# Altium schematic sheet [10] - HDMI.SchDoc
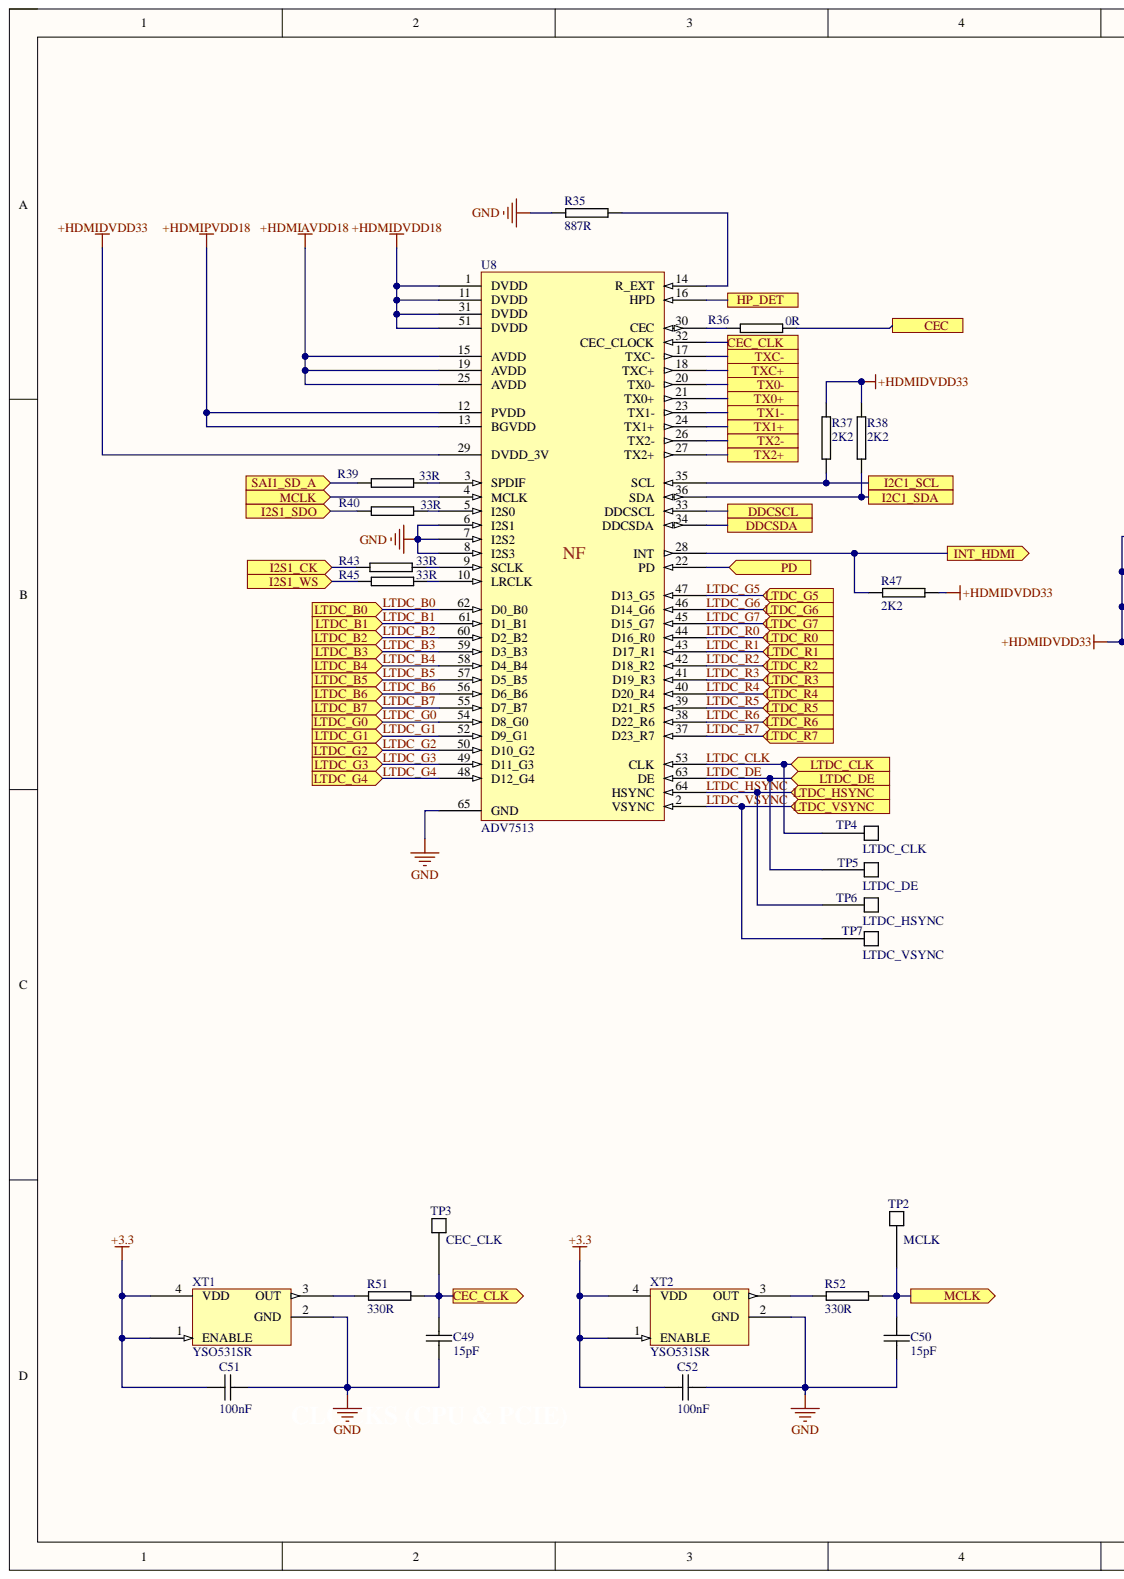
[diagram p0: [10] - HDMI.SchDoc, left]
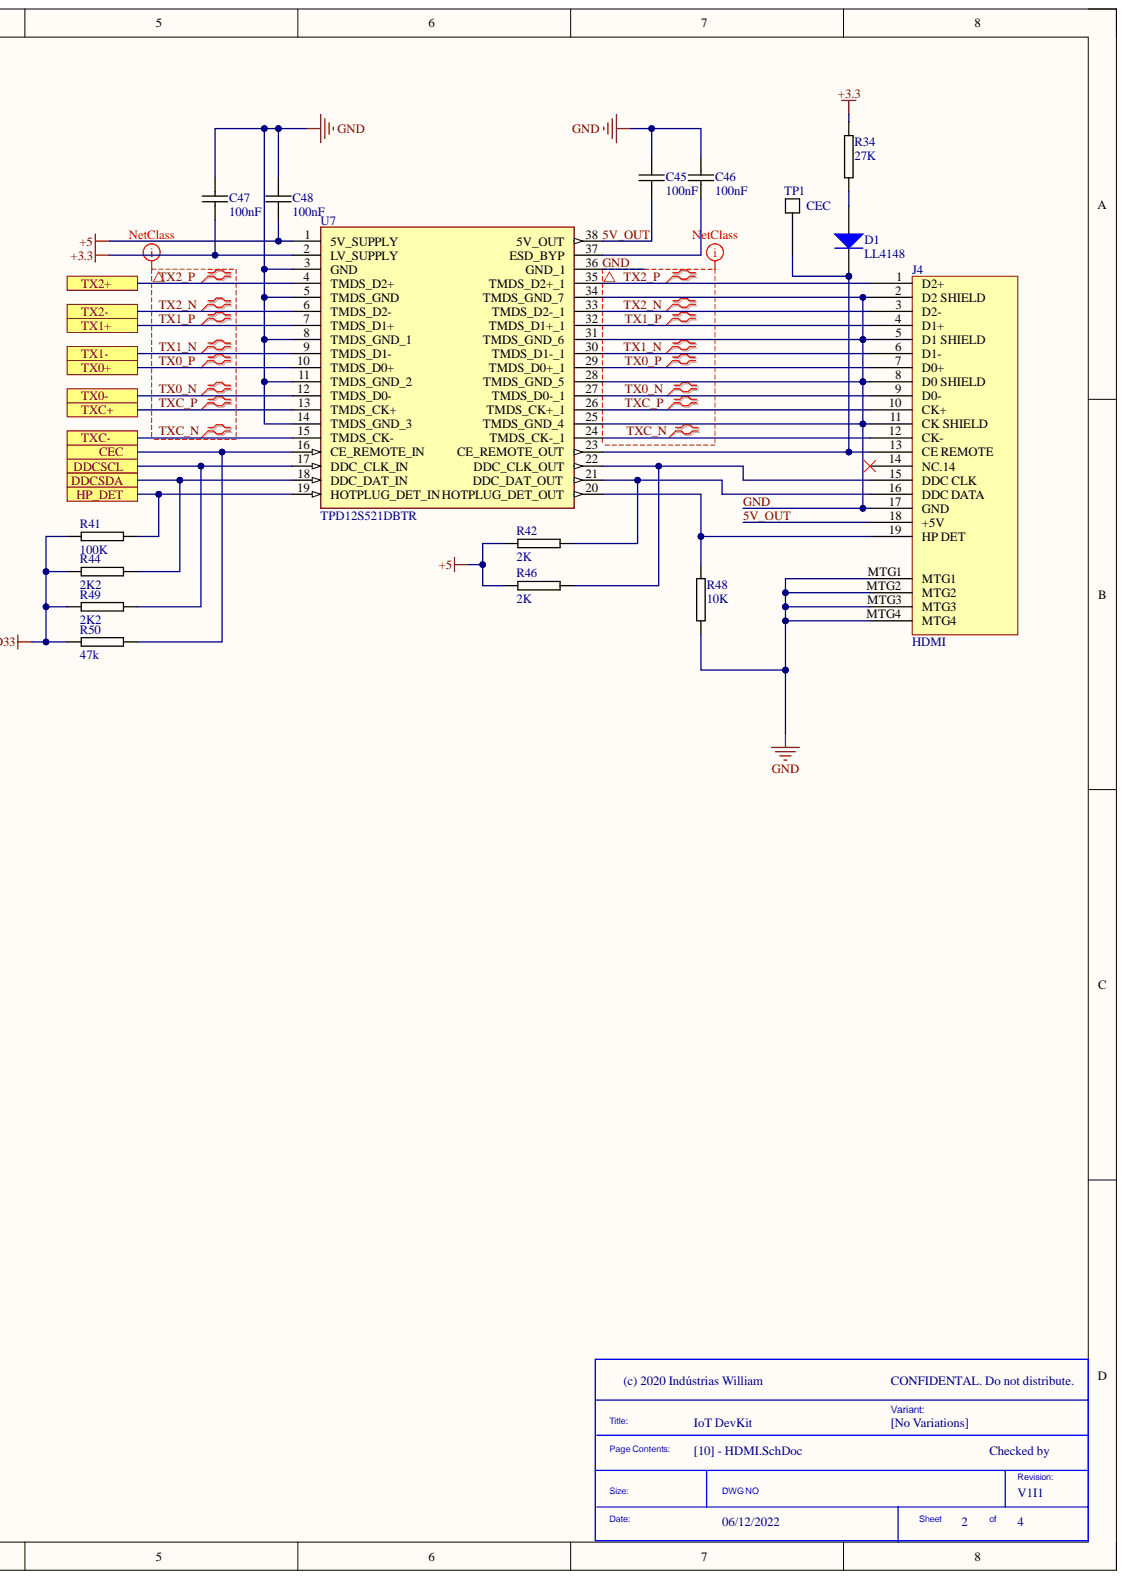
[diagram p1: [10] - HDMI.SchDoc, right]

## Parts
C45  100nF  [0603C-GENERIC]
C46  100nF  [0603C-GENERIC]
C47  100nF  [0603C-GENERIC]
C48  100nF  [0603C-GENERIC]
C49  15pF  [0603C-GENERIC]
C50  15pF  [0603C-GENERIC]
C51  100nF  [0603C-GENERIC]
C52  100nF  [0603C-GENERIC]
D1  LL4148  [MELFDIODE]
J4  HDMI  [HDMI]
R34  27K  [0603R-27K]
R35  887R  [0603R-100]
R36  0R  [0603R-0R]
R37  2K2  [0603R-2K2]
R38  2K2  [0603R-2K2]
R39  33R  [0603R-33]
R40  33R  [0603R-33]
R41  100K  [0603R-100K]
R42  2K  [0603R-GENERIC]
R43  33R  [0603R-33]
R44  2K2  [0603R-2K2]
R45  33R  [0603R-33]
R46  2K  [0603R-GENERIC]
R47  2K2  [0603R-2K2]
R48  10K  [0603R-10K]
R49  2K2  [0603R-2K2]
R50  47k  [0603R-2K2]
R51  330R  [0603R-330]
R52  330R  [0603R-330]
TP1  CEC  [TestPoint]
TP2  MCLK  [TestPoint]
TP3  CEC_CLK  [TestPoint]
TP4  LTDC_CLK  [TestPoint]
TP5  LTDC_DE  [TestPoint]
TP6  LTDC_HSYNC  [TestPoint]
TP7  LTDC_VSYNC  [TestPoint]
U7  TPD12S521DBTR  [SOP50P640X120-38N]
U8  ADV7513  [LQFP64]
XT1  YSO531SR  [CRISTAL]
XT2  YSO531SR  [CRISTAL]

## Ports
CEC, CEC_CLK, DDCSCL, DDCSDA, HP_DET, I2C1_SCL, I2C1_SDA, I2S1_CK, I2S1_SDO, I2S1_WS, INT_HDMI, LTDC_B0, LTDC_B1, LTDC_B2, LTDC_B3, LTDC_B4, LTDC_B5, LTDC_B6, LTDC_B7, LTDC_CLK, LTDC_DE, LTDC_G0, LTDC_G1, LTDC_G2, LTDC_G3, LTDC_G4, LTDC_G5, LTDC_G6, LTDC_G7, LTDC_HSYNC, LTDC_R0, LTDC_R1, LTDC_R2, LTDC_R3, LTDC_R4, LTDC_R5, LTDC_R6, LTDC_R7, LTDC_VSYNC, MCLK, PD, SAI1_SD_A, TX0+, TX0-, TX1+, TX1-, TX2+, TX2-, TXC+, TXC-

## Nets
+3.3: C47.2 C51.1 C52.1 R34.1 U7.2(LV_SUPPLY) XT1.1(ENABLE) XT1.4(VDD) XT2.1(ENABLE) XT2.4(VDD)
+HDMIAVDD18: U8.15(AVDD) U8.19(AVDD) U8.25(AVDD)
+HDMIDVDD18: U8.1(DVDD) U8.11(DVDD) U8.31(DVDD) U8.51(DVDD)
+HDMIDVDD33: R37.1 R38.1 R41.1 R44.1 R47.1 R49.1 R50.1 U8.29(DVDD_3V)
+HDMIPVDD18: U8.12(PVDD) U8.13(BGVDD)
5V_OUT: C45.2 C48.2 J4.18(+5V) R42.1 R46.1 U7.1(5V_SUPPLY) U7.38(5V_OUT)
CEC: R36.2 R50.2 U7.16(CE_REMOTE_IN) ; port CEC
CEC_CLK: C49.1 R51.2 TP3.1 U8.32(CEC_CLOCK) ; port CEC_CLK
DDCSCL: R49.2 U7.17(DDC_CLK_IN) U8.33(DDCSCL) ; port DDCSCL
DDCSDA: R44.2 U7.18(DDC_DAT_IN) U8.34(DDCSDA) ; port DDCSDA
GND: C45.1 C46.1 C47.1 C48.1 C49.2 C50.2 C51.2 C52.2 J4.2(D2_SHIELD) J4.5(D1_SHIELD) J4.8(D0_SHIELD) J4.11(CK_SHIELD) J4.17(GND) J4.MTG1 J4.MTG2 J4.MTG3 J4.MTG4 R35.1 R48.1 U7.3(GND) U7.5(TMDS_GND) U7.8(TMDS_GND_1) U7.11(TMDS_GND_2) U7.14(TMDS_GND_3) U7.25(TMDS_GND_4) U7.28(TMDS_GND_5) U7.31(TMDS_GND_6) U7.34(TMDS_GND_7) U7.36(GND_1) U8.6(I2S1) U8.7(I2S2) U8.8(I2S3) U8.65(GND) XT1.2(GND) XT2.2(GND)
HP_DET: R41.2 U7.19(HOTPLUG_DET_IN) U8.16(HPD) ; port HP_DET
I2C1_SCL: R37.2 U8.35(SCL) ; port I2C1_SCL
I2C1_SDA: R38.2 U8.36(SDA) ; port I2C1_SDA
I2S1_CK: R43.1 ; port I2S1_CK
I2S1_SDO: R40.1 ; port I2S1_SDO
I2S1_WS: R45.1 ; port I2S1_WS
INT_HDMI: R47.2 U8.28(INT) ; port INT_HDMI
LTDC_B0: U8.62(D0_B0) ; port LTDC_B0
LTDC_B1: U8.61(D1_B1) ; port LTDC_B1
LTDC_B2: U8.60(D2_B2) ; port LTDC_B2
LTDC_B3: U8.59(D3_B3) ; port LTDC_B3
LTDC_B4: U8.58(D4_B4) ; port LTDC_B4
LTDC_B5: U8.57(D5_B5) ; port LTDC_B5
LTDC_B6: U8.56(D6_B6) ; port LTDC_B6
LTDC_B7: U8.55(D7_B7) ; port LTDC_B7
LTDC_CLK: TP4.1 U8.53(CLK) ; port LTDC_CLK
LTDC_DE: TP5.1 U8.63(DE) ; port LTDC_DE
LTDC_G0: U8.54(D8_G0) ; port LTDC_G0
LTDC_G1: U8.52(D9_G1) ; port LTDC_G1
LTDC_G2: U8.50(D10_G2) ; port LTDC_G2
LTDC_G3: U8.49(D11_G3) ; port LTDC_G3
LTDC_G4: U8.48(D12_G4) ; port LTDC_G4
LTDC_G5: U8.47(D13_G5) ; port LTDC_G5
LTDC_G6: U8.46(D14_G6) ; port LTDC_G6
LTDC_G7: U8.45(D15_G7) ; port LTDC_G7
LTDC_HSYNC: TP6.1 U8.64(HSYNC) ; port LTDC_HSYNC
LTDC_R0: U8.44(D16_R0) ; port LTDC_R0
LTDC_R1: U8.43(D17_R1) ; port LTDC_R1
LTDC_R2: U8.42(D18_R2) ; port LTDC_R2
LTDC_R3: U8.41(D19_R3) ; port LTDC_R3
LTDC_R4: U8.40(D20_R4) ; port LTDC_R4
LTDC_R5: U8.39(D21_R5) ; port LTDC_R5
LTDC_R6: U8.38(D22_R6) ; port LTDC_R6
LTDC_R7: U8.37(D23_R7) ; port LTDC_R7
LTDC_VSYNC: TP7.1 U8.2(VSYNC) ; port LTDC_VSYNC
MCLK: C50.1 R52.2 TP2.1 U8.4(MCLK) ; port MCLK
PD: U8.22(PD) ; port PD
SAI1_SD_A: R39.1 ; port SAI1_SD_A
TX0_N: J4.9(D0-) U7.12(TMDS_D0-) U7.27(TMDS_D0-_1) U8.20(TX0-)
TX0_P: J4.7(D0+) U7.10(TMDS_D0+) U7.29(TMDS_D0+_1) U8.21(TX0+)
TX1_N: J4.6(D1-) U7.9(TMDS_D1-) U7.30(TMDS_D1-_1) U8.23(TX1-)
TX1_P: J4.4(D1+) U7.7(TMDS_D1+) U7.32(TMDS_D1+_1) U8.24(TX1+)
TX2_N: J4.3(D2-) U7.6(TMDS_D2-) U7.33(TMDS_D2-_1) U8.26(TX2-)
TX2_P: J4.1(D2+) U7.4(TMDS_D2+) U7.35(TMDS_D2+_1) U8.27(TX2+)
TXC_N: J4.12(CK-) U7.15(TMDS_CK-) U7.24(TMDS_CK-_1) U8.17(TXC-)
TXC_P: J4.10(CK+) U7.13(TMDS_CK+) U7.26(TMDS_CK+_1) U8.18(TXC+)
NetC46_2: C46.2 U7.37(ESD_BYP)
NetD1_1: D1.1 J4.13(CE_REMOTE) TP1.1 U7.23(CE_REMOTE_OUT)
NetD1_2: D1.2 R34.2
NetJ4_15: J4.15(DDC_CLK) R46.2 U7.22(DDC_CLK_OUT)
NetJ4_16: J4.16(DDC_DATA) R42.2 U7.21(DDC_DAT_OUT)
NetJ4_19: J4.19(HP_DET) R48.2 U7.20(HOTPLUG_DET_OUT)
NetR35_2: R35.2 U8.14(R_EXT)
NetR36_1: R36.1 U8.30(CEC)
NetR39_2: R39.2 U8.3(SPDIF)
NetR40_2: R40.2 U8.5(I2S0)
NetR43_2: R43.2 U8.9(SCLK)
NetR45_2: R45.2 U8.10(LRCLK)
NetR51_1: R51.1 XT1.3(OUT)
NetR52_1: R52.1 XT2.3(OUT)

Not connected: J4 14(NC.14)

## Library data of the parts
C45-C48 C51 C52 = 0603C-100NF-25V-X7R-TDK: CAPACITOR 0603 CERAMIC; Manufacturer: TDK Corporation; Manufacturer Part Number: C1608X7R1E104K; Reel: C12; Component Kind: Standard; Library Name: vh_altium_library.intLib; Supplier 1: Digi-Key; Supplier Part Number 1: 445-1316-2-ND
C49 C50 = 0603C-15PF-50V-C0G-MUR: CAPACITOR 0603 CERAMIC; Reel: C2; Component Kind: Standard; Library Name: vh_altium_library.intLib
D1 = 1N4148: Small Signal Fast Switching Diode; Component Kind: Standard; Library Name: Componentes Deived Projetos.IntLib
J4 = HDMI: CONECTOR HDMI; Component Kind: Standard; Library Name: Componentes Deived Projetos.IntLib
R34 = 0603R-27K: GENERIC RESISTOR 0603; Manufacturer: Stackpole Electronics Inc; Manufacturer Part Number: RMC 1/16 27K 1% R; Power (Watts): 0.1W, 1/10W; Resistance In Ohms: 27.0K; Temperature Coefficient: ±100ppm/°C; Tolerance: ±1%; Case: 0603 (1608 metric); Category: Resistors; Component Kind: Standard; Composition: Thick Film; Lead Style: Surface Mount (SMD - SMT); Library Name: vh_altium_library.intLib; Packaging: Tape & Reel (TR); RoHS: RoHS Compliant; Supplier: Digi-Key; Supplier 1: Digi-Key; Supplier Part Number: RMC1/1627KFR-ND; Supplier Part Number 1: RMC1/1627KFR-ND
R35 = 0603R-100: GENERIC RESISTOR 0603; Manufacturer: Stackpole Electronics Inc; Manufacturer Part Number: RMCF 1/16 100 1% R; Reel: R24; Component Kind: Standard; Library Name: vh_altium_library.intLib; Supplier 1: Digi-Key; Supplier Part Number 1: RMCF1/16100FRDKR-ND
R36 = 0603R-0R: GENERIC JUMPER 0603; Manufacturer: Stackpole Electronics Inc; Manufacturer Part Number: RMC 1/16 0 G; Reel: R26; Component Kind: Standard; Library Name: vh_altium_library.intLib; Supplier 1: Digi-Key; Supplier Part Number 1: RMCF0603ZT0R00TR-ND
R37 R38 R44 R47 R49 R50 = 0603R-2K2: GENERIC RESISTOR 0603; Manufacturer: Stackpole Electronics Inc; Manufacturer Part Number: RMC 1/16 2.2K 1% R; REEL: R88; Component Kind: Standard; Library Name: vh_altium_library.intLib; Supplier 1: Digi-Key; Supplier Part Number 1: RMCF0603FT2K20TR-ND
R39 R40 R43 R45 = 0603R-33R: GENERIC RESISTOR 0603; Manufacturer: Stackpole Electronics Inc; Manufacturer Part Number: RMC 1/16 33 1% G; Power (Watts): 0.1W, 1/10W; Reel: R18; Resistance In Ohms: 33.0; Temperature Coefficient: ±100ppm/°C; Tolerance: ±1%; Case: 0603 (1608 metric); Category: Resistors; Component Kind: Standard; Composition: Thick Film; Lead Style: Surface Mount (SMD - SMT); Library Name: vh_altium_library.intLib; Packaging: Tape & Reel (TR); RoHS: RoHS Compliant; Supplier: Digi-Key; Supplier 1: Digi-Key; Supplier Part Number: RMC1/1633FG-ND; Supplier Part Number 1: RMCF0603FT33R0TR-ND
R41 = 0603R-100K: GENERIC RESISTOR 0603; Manufacturer: Stackpole Electronics Inc; Manufacturer Part Number: RMCF 1/16 100K 1% R; Power (Watts): 0.1W, 1/10W; REEL: R47; Temperature Coefficient: ±100ppm/°C; Tolerance: ±1%; Case: 0603 (1608 metric); Category: Resistors; Component Kind: Standard; Composition: Thick Film; Lead Style: Surface Mount (SMD - SMT); Library Name: vh_altium_library.intLib; Packaging: Cut Tape (CT); Resistance In Ohms: 100K; RoHS: RoHS Compliant; Supplier: Digi-Key; Supplier 1: Digi-Key; Supplier Part Number: RMCF1/16100KFRCT-ND; Supplier Part Number 1: RMCF0603FT100KTR-ND
R42 R46 = 0603R-2K: GENERIC RESISTOR 0603; Manufacturer: Stackpole Electronics Inc; Manufacturer Part Number: RMCF 1/16 2K 1% R; Power (Watts): 0.1W, 1/10W; Reel: R9; Resistance In Ohms: 2.00K; Temperature Coefficient: ±100ppm/°C; Tolerance: ±1%; Case: 0603 (1608 metric); Category: Resistors; Component Kind: Standard; Composition: Thick Film; Lead Style: Surface Mount (SMD - SMT); Library Name: vh_altium_library.intLib; Packaging: Tape & Reel (TR); RoHS: RoHS Compliant; Supplier: Digi-Key; Supplier 1: Digi-Key; Supplier Part Number: RMCF1/162KFRTR-ND; Supplier Part Number 1: RMCF1/162KFRTR-ND
R48 = 0603R-10K: GENERIC RESISTOR 0603; Manufacturer: Stackpole Electronics Inc; Manufacturer Part Number: RMCF 1/16 10K 1% R; Power (Watts): 0.1W, 1/10W; Reel: R21; Resistance In Ohms: 10.0K; Temperature Coefficient: ±100ppm/°C; Tolerance: ±1%; Case: 0603 (1608 metric); Category: Resistors; Component Kind: Standard; Composition: Thick Film; Lead Style: Surface Mount (SMD - SMT); Library Name: vh_altium_library.intLib; Packaging: Digi-Reel®; RoHS: RoHS Compliant; Supplier: Digi-Key; Supplier 1: Digi-Key; Supplier Part Number: RMCF1/1610KFRDKR-ND; Supplier Part Number 1: RMCF0603FT10K0DKR-ND
R51 R52 = 0603R-330: GENERIC RESISTOR 0603; Manufacturer: Stackpole Electronics Inc; Manufacturer Part Number: RMCF 1/16 330 1% R; Power (Watts): 0.1W, 1/10W; Resistance In Ohms: 330; Temperature Coefficient: ±100ppm/°C; Tolerance: ±1%; Case: 0603 (1608 metric); Category: Resistors; Component Kind: Standard; Composition: Thick Film; Lead Style: Surface Mount (SMD - SMT); Library Name: vh_altium_library.intLib; Packaging: Cut Tape (CT); RoHS: RoHS Compliant; Supplier: Digi-Key; Supplier 1: Digi-Key; Supplier Part Number: RMCF1/16330FRCT-ND; Supplier Part Number 1: RMCF1/16330FRCT-ND
TP1-TP7 = TestPoint: TESTE POINT; Component Kind: Standard; Library Name: Componentes Deived Projetos.IntLib
U7 = TPD12S521DBTR: The TPD12S521 is a single-chip electro-static  discharge (ESD) circuit protection device for the highdefinition multimedia interface (HDMI) transmitter  port.; Manufacturer_Name: Texas Instruments; Arrow Part Number: TPD12S521DBTR; Arrow Price/Stock: https://www.arrow.com/en/products/tpd12s521dbtr/texas-instruments; Component Kind: Standard; Datasheet Link: http://www.ti.com/lit/ds/symlink/tpd12s521.pdf; Height: 1.2mm; Manufacturer_Part_Number: TPD12S521DBTR; Mouser Part Number: 595-TPD12S521DBTR; Mouser Price/Stock: https://www.mouser.co.uk/ProductDetail/Texas-Instruments/TPD12S521DBTR?qs=XGzIaZb%2FFYI8fSEzXwwy6A%3D%3D; Library Name: Componentes Deived Projetos.IntLib
U8 = ADV7513: High Perfomance HDMI Trasmitter; Component Kind: Standard; Library Name: Componentes Deived Projetos.SchLib
XT1 XT2 = YSO531SR: Cristal Oscilator Active 1.8v - 3.3v; Component Kind: Standard; Library Name: Componentes Deived Projetos.IntLib
